# Altium schematic sheet In-Out.SchDoc(In-Out)
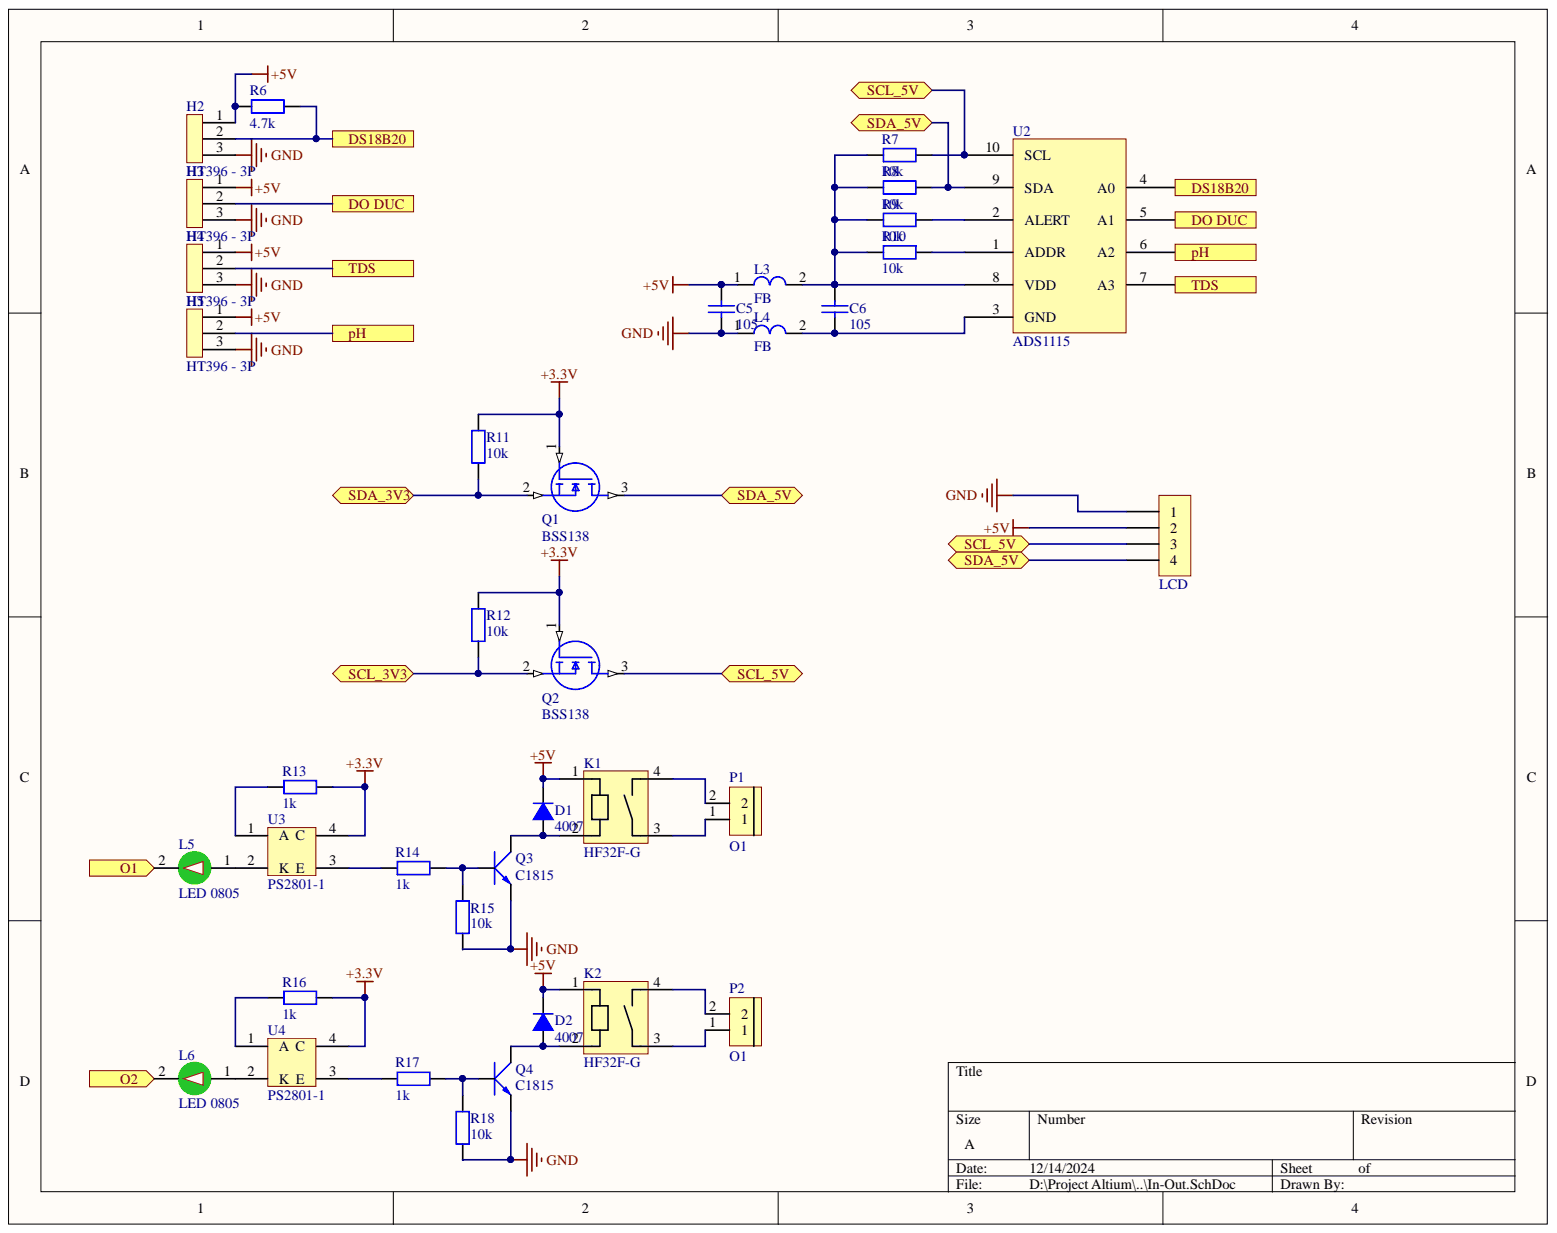
[diagram p0: In-Out.SchDoc]

## Parts
C5  105  [tu 0805]
C6  105  [tu 0805]
D1  4007  [1n4148_SOD123]
D2  4007  [1n4148_SOD123]
H2  HT396 - 3P  [HT396 - 3P]
H3  HT396 - 3P  [HT396 - 3P]
H4  HT396 - 3P  [HT396 - 3P]
H5  HT396 - 3P  [HT396 - 3P]
H6  LCD  [XH2.54M-4]
K1  HF32F-G  [HF32F-G]
K2  HF32F-G  [HF32F-G]
L3  FB  [FB0805 30 Ohm]
L4  FB  [FB0805 30 Ohm]
L5  LED 0805  [LED-0805-Green]
L6  LED 0805  [LED-0805-Green]
P1  O1  [CON 2 2EDG5.08]
P2  O1  [CON 2 2EDG5.08]
Q1  BSS138  [SOT-23]
Q2  BSS138  [SOT-23]
Q3  C1815  [SOT23B]
Q4  C1815  [SOT23B]
R6  4.7k  [tro smd 0603]
R7  10k  [tro smd 0603]
R8  10k  [tro smd 0603]
R9  10k  [tro smd 0603]
R10  10k  [tro smd 0603]
R11  10k  [tro smd 0603]
R12  10k  [tro smd 0603]
R13  1k  [tro smd 0603]
R14  1k  [tro smd 0603]
R15  10k  [tro smd 0603]
R16  1k  [tro smd 0603]
R17  1k  [tro smd 0603]
R18  10k  [tro smd 0603]
U2  ADS1115  [ADS1115]
U3  PS2801-1  [PS2801]
U4  PS2801-1  [PS2801]

## Ports
DO DUC, DS18B20, O1, O2, pH, SCL_3V3, SCL_5V, SDA_3V3, SDA_5V, TDS

## Nets
+3.3V: Q1.1 Q2.1 R11.1 R12.1 R13.2 R16.2 U3.4(C) U4.4(C)
+5V: C5.1 D1.2 D2.2 H2.1 H3.1 H4.1 H5.1 H6.2 K1.1 K2.1 L3.1 R6.1
GND: C5.2 H2.3 H3.3 H4.3 H5.3 H6.1 L4.1 Q3.1 Q4.1 R15.1 R18.1
DO DUC: H3.2 U2.5(A1) ; port DO DUC
DS18B20: H2.2 R6.2 U2.4(A0) ; port DS18B20
O1: L5.2 ; port O1
O2: L6.2 ; port O2
pH: H5.2 U2.6(A2) ; port pH
SCL_3V3: Q2.2 R12.2 ; port SCL_3V3
SCL_5V: H6.3 Q2.3 R7.2 U2.10(SCL) ; port SCL_5V
SDA_3V3: Q1.2 R11.2 ; port SDA_3V3
SDA_5V: H6.4 Q1.3 R8.2 U2.9(SDA) ; port SDA_5V
TDS: H4.2 U2.7(A3) ; port TDS
NetC6_1: C6.1 L3.2 R7.1 R8.1 R9.2 R10.2 U2.8(VDD)
NetC6_2: C6.2 L4.2 U2.3(GND)
NetD1_1: D1.1 K1.2 Q3.2
NetD2_1: D2.1 K2.2 Q4.2
NetK1_3: K1.3 P1.1
NetK1_4: K1.4 P1.2
NetK2_3: K2.3 P2.1
NetK2_4: K2.4 P2.2
NetL5_1: L5.1 U3.2(K)
NetL6_1: L6.1 U4.2(K)
NetQ3_3: Q3.3 R14.2 R15.2
NetQ4_3: Q4.3 R17.2 R18.2
NetR9_1: R9.1 U2.2(ALERT)
NetR10_1: R10.1 U2.1(ADDR)
NetR13_1: R13.1 U3.1(A)
NetR14_1: R14.1 U3.3(E)
NetR16_1: R16.1 U4.1(A)
NetR17_1: R17.1 U4.3(E)

## Library data of the parts
C5 C6 = Tu 0805 105 50V 10%: Dán; Ten_Linh_Kien: Tụ 0805 105-1uF 10% 50V; So_Chan: 2; Ma_Hang: MH004552; Don_Vi: Con; Library Name: Tu dien.SchLib; Component Kind: Standard; Chung_Loai: Dán
D1 D2 = 1N4007-SMD: Schottky Diode; Manufacturer_Part_Number: 1N5819HWQ-7-F; Manufacturer_Name: Diodes Inc.; Mouser Price/Stock: https://www.mouser.co.uk/ProductDetail/Diodes-Incorporated/1N5819HWQ-7-F?qs=gZXFycFWdAPDr8BmsK1ygg%3D%3D; Mouser Part Number: 621-1N5819HWQ-7-F; Library Name: test.SchLib; Height: 1.45mm; Datasheet Link: https://eu.mouser.com/datasheet/2/115/ds30217-1532212.pdf; Component Kind: Standard; Arrow Price/Stock: https://www.arrow.com/en/products/1n5819hwq-7-f/diodes-incorporated?region=nac; Arrow Part Number: 1N5819HWQ-7-F
H2-H5 = HT396 - 3P: Header terminal HT396 3.96mm 3P; Library Name: test.SchLib; Component Kind: Standard
H6 = Header 4P 2.54 Duc Thang: Cắm; Ten_Linh_Kien: Header 4P XH2.54-4P Đực Thẳng; Ma_Hang: MH001285; Don_Vi: Con; Library Name: Header.SchLib; Component Kind: Standard; Chung_Loai: Cắm
L3 L4 = FB0805 30ohm: Ferrite 0805 30Ohm; Library Name: test.SchLib; Component Kind: Standard
L5 L6 = LED 0805 Xanh La: Dán; Ten_Linh_Kien: LED 0805 Màu Xanh Lá (Green); Ma_Hang: MH002326; Don_Vi: Con; Library Name: Led.SchLib; Component Kind: Standard; Chung_Loai: Dán
P1 P2 = CON 2 EDG5.08: Cắm; Ten_Linh_Kien: CON 2 2EDG5.08-2P 5.08MM ( Xanh Cong); Ma_Hang: MH000759; Don_Vi: Con; Library Name: Connector.SchLib; Component Kind: Standard; Chung_Loai: Cắm
Q1 Q2 = BSS138: mosfet N; Library Name: test.SchLib; Component Kind: Standard
Q3 Q4 = C1815: NPN 600mW, ECB; Value: C1815; Component Kind: Standard; : C2383; : C828; Library Name: syhaunguyen.SchLib
R6 = Tro 0603 5% 4.7k: Dán; Ten_Linh_Kien: Trở 0603 5% 4.7k; So_Chan: 2; Ma_Hang: MH006327; Don_Vi: Con; Library Name: Dien Tro.SchLib; Component Kind: Standard; Chung_Loai: Dán
R7-R12 R15 R18 = Tro 0603 5% 10k: Dán; Ten_Linh_Kien: Trở 0603 5% 10k; So_Chan: 2; Ma_Hang: MH006316; Don_Vi: Con; Library Name: Dien Tro.SchLib; Component Kind: Standard; Chung_Loai: Dán
R13 R14 R16 R17 = Tro 0603 5% 1k: Dán; Ten_Linh_Kien: Trở 0603 5% 1k; So_Chan: 2; Ma_Hang: MH006319; Don_Vi: Con; Library Name: Dien Tro.SchLib; Component Kind: Standard; Chung_Loai: Dán
U2 = ADS1115: IC ADS1115 ADC 16BIT 4CHANNEL; Library Name: test.SchLib; Component Kind: Standard
K1 K2 = HF32F-G: Library Name: test.SchLib; Component Kind: Standard
U3 U4 = PS2801-1: Library Name: test.SchLib; Component Kind: Standard
